# Altium schematic sheet root.SchDoc
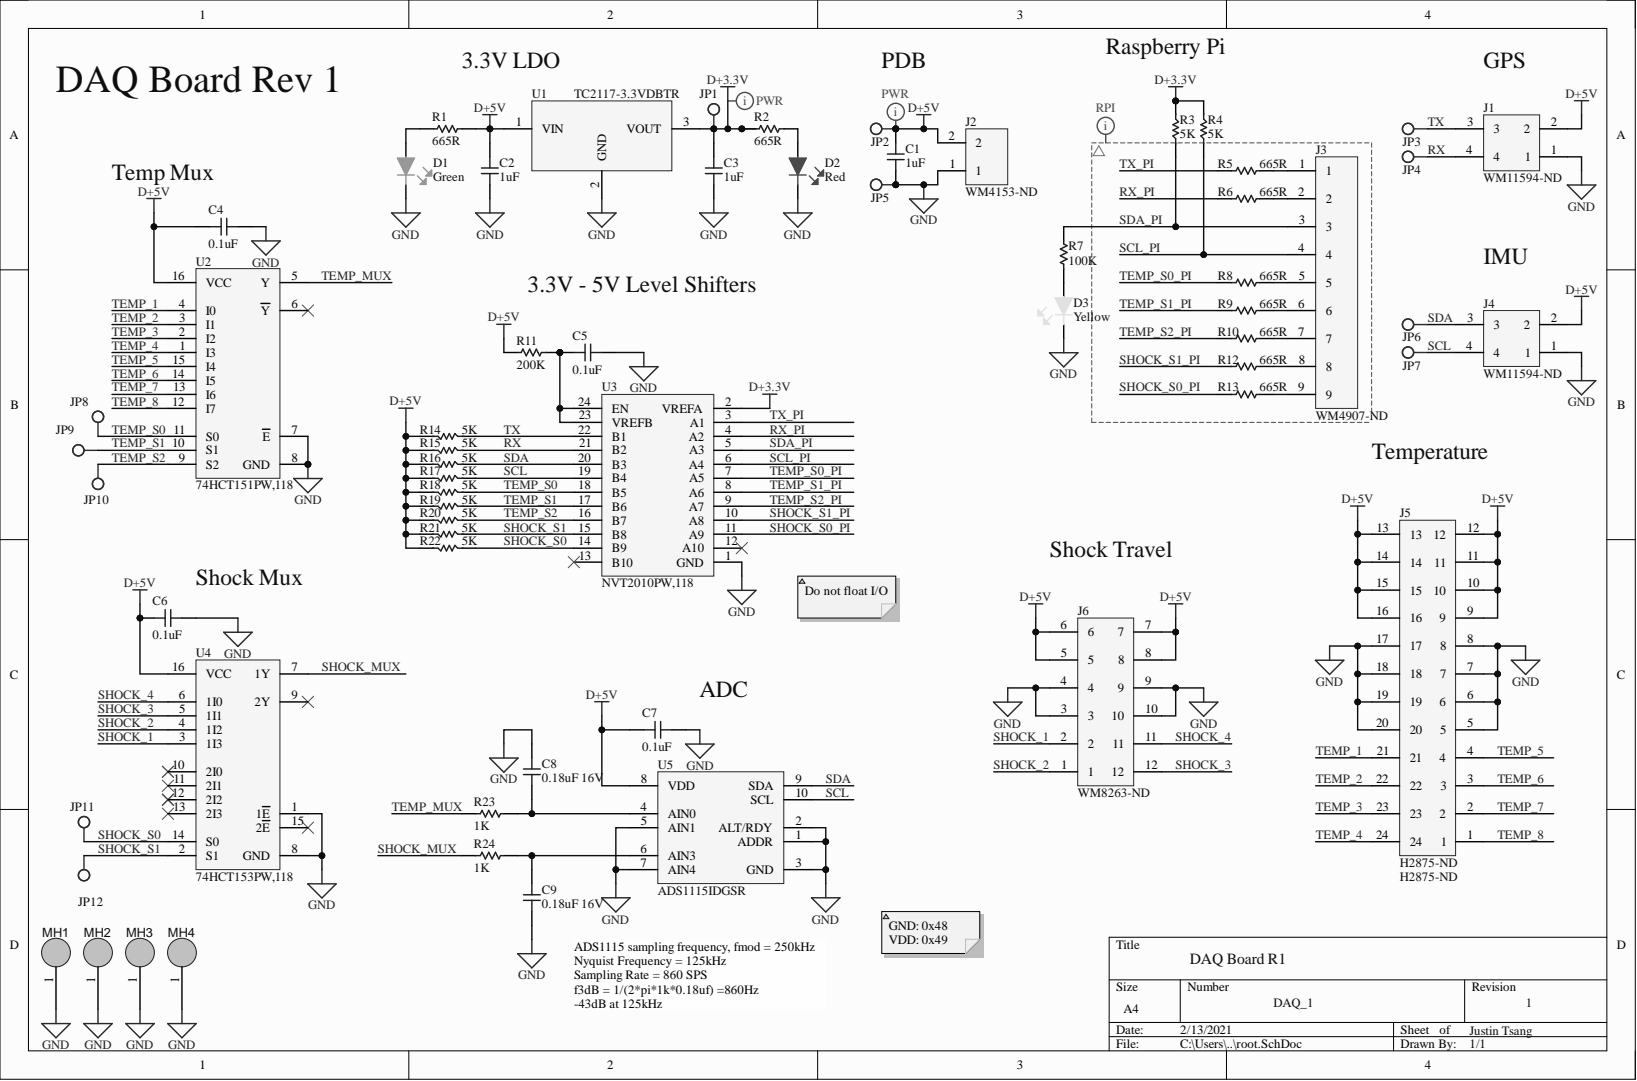
[diagram p0: root.SchDoc]

## Parts
C1  1uF  [CAP-0603]
C2  1uF  [CAP-0603]
C3  1uF  [CAP-0603]
C4  0.1uF  [CAP-0603]
C5  0.1uF  [CAP-0603]
C6  0.1uF  [CAP-0603]
C7  0.1uF  [CAP-0603]
C8  0.18uF 16V  [CAP-0603]
C9  0.18uF 16V  [CAP-0603]
D1  Green  [LED-0603]
D2  Red  [LED-0603]
D3  Yellow  [LED-0603]
J1  WM11594-ND  [2X2PIN]
J2  WM4153-ND  [2PIN]
J3  WM4907-ND  [9PIN]
J4  WM11594-ND  [2X2PIN]
J5  H2875-ND  [2X12PIN]
J6  WM8263-ND  [2X6PIN]
JP1  Testpoint2  [TP2]  (value not drawn)
JP2  Testpoint2  [TP2]  (value not drawn)
JP3  Testpoint2  [TP2]  (value not drawn)
JP4  Testpoint2  [TP2]  (value not drawn)
JP5  Testpoint2  [TP2]  (value not drawn)
JP6  Testpoint2  [TP2]  (value not drawn)
JP7  Testpoint2  [TP2]  (value not drawn)
JP8  Testpoint2  [TP2]  (value not drawn)
JP9  Testpoint2  [TP2]  (value not drawn)
JP10  Testpoint2  [TP2]  (value not drawn)
JP11  Testpoint2  [TP2]  (value not drawn)
JP12  Testpoint2  [TP2]  (value not drawn)
MH1  MountingHole  [MH4.2MM]  (value not drawn)
MH2  MountingHole  [MH4.2MM]  (value not drawn)
MH3  MountingHole  [MH4.2MM]  (value not drawn)
MH4  MountingHole  [MH4.2MM]  (value not drawn)
R1  665R  [RES-0603]
R2  665R  [RES-0603]
R3  5K  [RES-0603]
R4  5K  [RES-0603]
R5  665R  [RES-0603]
R6  665R  [RES-0603]
R7  100K  [RES-0603]
R8  665R  [RES-0603]
R9  665R  [RES-0603]
R10  665R  [RES-0603]
R11  200K  [RES-0603]
R12  665R  [RES-0603]
R13  665R  [RES-0603]
R14  5K  [RES-0603]
R15  5K  [RES-0603]
R16  5K  [RES-0603]
R17  5K  [RES-0603]
R18  5K  [RES-0603]
R19  5K  [RES-0603]
R20  5K  [RES-0603]
R21  5K  [RES-0603]
R22  5K  [RES-0603]
R23  1K  [RES-0603]
R24  1K  [RES-0603]
U1  TC2117-3.3VDBTR  [SOT223]
U2  74HCT151PW,118  [TSSOP65P660X110-16]
U3  NVT2010PW,118  [TSSOP65P660X110-24]
U4  74HCT153PW,118  [TSSOP65P660X110-16]
U5  ADS1115IDGSR  [VSSOP50P310X310-10P]

## Nets
D+3.3V: C3.2 JP1.1 R2.2 R3.2 R4.2 U1.3 U3.2(VREFA)
D+5V: C1.2 C2.2 C4.2 C6.2 C7.2 J1.2 J2.2 J4.2 J5.9 J5.10 J5.11 J5.12 J5.13 J5.14 J5.15 J5.16 J6.5 J6.6 J6.7 J6.8 JP2.1 R1.1 R11.2 R14.2 R15.1 R16.1 R17.1 R18.1 R19.1 R20.1 R21.1 R22.1 U1.1 U2.16(VCC) U4.16(VCC) U5.8(VDD)
GND: C1.1 C2.1 C3.1 C4.1 C5.1 C6.1 C7.1 C8.1 C9.1 D1.2 D2.2 D3.2 J1.1 J2.1 J4.1 J5.5 J5.6 J5.7 J5.8 J5.17 J5.18 J5.19 J5.20 J6.3 J6.4 J6.9 J6.10 JP5.1 MH1.1 MH2.1 MH3.1 MH4.1 U1.2 U2.7(E) U2.8(GND) U3.1(GND) U4.1(1E) U4.8(GND) U5.1(ADDR) U5.2(ALT/RDY) U5.3(GND) U5.5(AIN1) U5.7(AIN4)
RX: J1.4 JP4.1 R15.2 U3.21(B2)
RX_PI: R6.1 U3.4(A2)
SCL: J4.4 JP7.1 R17.2 U3.19(B4) U5.10(SCL)
SCL_PI: J3.4 R4.1 U3.6(A4)
SDA: J4.3 JP6.1 R16.2 U3.20(B3) U5.9(SDA)
SDA_PI: J3.3 R3.1 R7.2 U3.5(A3)
SHOCK_1: J6.2 U4.3(1I3)
SHOCK_2: J6.1 U4.4(1I2)
SHOCK_3: J6.12 U4.5(1I1)
SHOCK_4: J6.11 U4.6(1I0)
SHOCK_MUX: R24.1 U4.7(1Y)
SHOCK_S0: JP11.1 R22.2 U3.14(B9) U4.14(S0)
SHOCK_S0_PI: R13.1 U3.11(A9)
SHOCK_S1: JP12.1 R21.2 U3.15(B8) U4.2(S1)
SHOCK_S1_PI: R12.1 U3.10(A8)
TEMP_1: J5.21 U2.4(I0)
TEMP_2: J5.22 U2.3(I1)
TEMP_3: J5.23 U2.2(I2)
TEMP_4: J5.24 U2.1(I3)
TEMP_5: J5.4 U2.15(I4)
TEMP_6: J5.3 U2.14(I5)
TEMP_7: J5.2 U2.13(I6)
TEMP_8: J5.1 U2.12(I7)
TEMP_MUX: R23.1 U2.5(Y)
TEMP_S0: JP8.1 R18.2 U2.11(S0) U3.18(B5)
TEMP_S0_PI: R8.1 U3.7(A5)
TEMP_S1: JP9.1 R19.2 U2.10(S1) U3.17(B6)
TEMP_S1_PI: R9.1 U3.8(A6)
TEMP_S2: JP10.1 R20.2 U2.9(S2) U3.16(B7)
TEMP_S2_PI: R10.1 U3.9(A7)
TX: J1.3 JP3.1 R14.1 U3.22(B1)
TX_PI: R5.1 U3.3(A1)
NetC5_2: C5.2 R11.1 U3.23(VREFB) U3.24(EN)
NetC8_2: C8.2 R23.2 U5.4(AIN0)
NetC9_2: C9.2 R24.2 U5.6(AIN3)
NetD1_1: D1.1 R1.2
NetD2_1: D2.1 R2.1
NetD3_1: D3.1 R7.1
NetJ3_1: J3.1 R5.2
NetJ3_2: J3.2 R6.2
NetJ3_5: J3.5 R8.2
NetJ3_6: J3.6 R9.2
NetJ3_7: J3.7 R10.2
NetJ3_8: J3.8 R12.2
NetJ3_9: J3.9 R13.2

Not connected: U2 6(Y); U3 12(A10) 13(B10); U4 9(2Y) 10(2I0) 11(2I1) 12(2I2) 13(2I3) 15(2E)

## Library data of the parts
C1-C3 = 0603-1uF-16V: CAP CER 1UF 16V X7R 0603; Manufacturer: KEMET; Manufacturer Part Number: C0603C105K4RACTU; Dielectric Material: Ceramic; Dissipation Factor: 3.5%; Tolerance: 10%; Capacitance: 1uF; Case Code (Imperial): 0603; Case Code (Metric): 1608; Case/Package: 0603; Component Kind: Standard; ComponentLink1Description: http://www.mouser.com/ds/2/212/KEM_C1002_X7R_SMD-1102033.pdf?src-supplier=Mouser; ComponentLink1URL: http://www.mouser.com/ds/2/212/KEM_C1002_X7R_SMD-1102033.pdf?src-supplier=Mouser; ComponentLink2Description: http://www.kemet.com/kemet/web/homepage/kechome.nsf/file/Commercial%20Ceramic%20Chips%20X7R%20Dielectric/$file/KEM_C1002_X7R_SMD.pdf?src-supplier=Element14; ComponentLink2URL: http://www.kemet.com/kemet/web/homepage/kechome.nsf/file/Commercial%20Ceramic%20Chips%20X7R%20Dielectric/$file/KEM_C1002_X7R_SMD.pdf?src-supplier=Element14; ComponentLink3Description: https://www.verical.com/server-webapp/html/getDatasheet?partID=385479&src-supplier=Verical; ComponentLink3URL: https://www.verical.com/server-webapp/html/getDatasheet?partID=385479&src-supplier=Verical; ComponentLink4Description: http://www.kemet.com/kemet/web/homepage/kechome.nsf/vapubfiles/KEM_C1002_X7R_SMD.pdf/$file/KEM_C1002_X7R_SMD.pdf?src-supplier=Element14; ComponentLink4URL: http://www.kemet.com/kemet/web/homepage/kechome.nsf/vapubfiles/KEM_C1002_X7R_SMD.pdf/$file/KEM_C1002_X7R_SMD.pdf?src-supplier=Element14; Contact Plating: Tin,Matte; Depth: 0.8mm; Dielectric: X7R; Height: 0.8mm; Length: 1.6mm; Library Name: Capacitors.SchLib; Max Operating Temperature: 125°C; Min Operating Temperature: -55°C; Mount: Surface Mount,PCB; Number of Pins: 2; Package Quantity: 4000; Packaging: Tape and Reel; Pricing 1: 1=0.12, 10=0.088, 100=0.0391, 500=0.02792, 1000=0.02193 (USD); Radiation Hardening: No; REACH SVHC: No SVHC; Stock 1: 600463; Supplier 1: Digi-Key; Supplier Part Number 1: 399-7847-6-ND; Termination: SMD/SMT; Thickness: 0.034inch; Voltage: 16V; Voltage Rating: 16V; Voltage Rating (DC): 16V; Width: 0.031inch
C4-C7 = 0603-0.1uF-16V: CAP CER 0.1UF 16V X7R 0603; Manufacturer: KEMET; Manufacturer Part Number: C0603C104M4RACTU; Capacitance: 100nF; Dielectric Material: Ceramic; Tolerance: 20%; Case Code (Imperial): 0603; Case Code (Metric): 1608; Case/Package: 0603; Component Kind: Standard; ComponentLink1Description: https://www.verical.com/server-webapp/html/getDatasheet?partID=66190&src-supplier=Verical; ComponentLink1URL: https://www.verical.com/server-webapp/html/getDatasheet?partID=66190&src-supplier=Verical; ComponentLink2Description: http://www.farnell.com/datasheets/2746055.pdf?src-supplier=Element14; ComponentLink2URL: http://www.farnell.com/datasheets/2746055.pdf?src-supplier=Element14; ComponentLink3Description: http://www.farnell.com/datasheets/2123765.pdf?src-supplier=Element14; ComponentLink3URL: http://www.farnell.com/datasheets/2123765.pdf?src-supplier=Element14; ComponentLink4Description: http://www.alliedelec.com/m/d/9bfe7773041ffe9d406e68bc7b377fdd.pdf?src-supplier=Allied+Electronics; ComponentLink4URL: http://www.alliedelec.com/m/d/9bfe7773041ffe9d406e68bc7b377fdd.pdf?src-supplier=Allied+Electronics; ComponentLink5Description: https://www.verical.com/server-webapp/html/getDatasheet?partID=302288&src-supplier=Verical; ComponentLink5URL: https://www.verical.com/server-webapp/html/getDatasheet?partID=302288&src-supplier=Verical; Depth: 0.8mm; Dielectric: X7R; Height: 0.8mm; Lead Pitch: 0.7mm; Length: 1.6mm; Library Name: Capacitors.SchLib; Max Operating Temperature: 125°C; Min Operating Temperature: -55°C; Mount: Surface Mount,PCB; Number of Pins: 2; Package Quantity: 1; Packaging: Tape and Reel; Pricing 1: 1=0.1, 10=0.036, 100=0.0161, 500=0.01152, 1000=0.00904 (USD); Radiation Hardening: No; REACH SVHC: No SVHC; Stock 1: 254524; Supplier 1: Digi-Key; Supplier Part Number 1: 399-1099-6-ND; Termination: SMD/SMT; Thickness: 0.034inch; Voltage Rating: 16V; Voltage Rating (DC): 16V; Width: 0.031inch
C8 C9 = 0603-0.18uF-16V: Cap Ceramic 0.18uF 16V X7R 10% SMD 0603 125C Paper T/R; Manufacturer: Yageo; Manufacturer Part Number: CC0603KRX7R7BB184; Capacitance: 180nF; Case Code (Imperial): 0603; Case Code (Metric): 1608; Case/Package: 0603; Category: Ceramic Capacitors; Component Kind: Standard; ComponentLink1Description: https://datasheet.ciiva.com/11465/upy-gphc-x7r-6-3v-to-50v-8-11465175.pdf?src-supplier=Avnet; ComponentLink1URL: https://datasheet.ciiva.com/11465/upy-gphc-x7r-6-3v-to-50v-8-11465175.pdf?src-supplier=Avnet; ComponentLink2Description: https://datasheet.ciiva.com/17434/upy-gphc-x7r-6-3v-to-50v-8-17434591.pdf?src-supplier=Avnet+Europe; ComponentLink2URL: https://datasheet.ciiva.com/17434/upy-gphc-x7r-6-3v-to-50v-8-17434591.pdf?src-supplier=Avnet+Europe; Construction: Flat; Depth: 0.8mm; Dielectric: X7R; Halogen Free: Halogen Free; Lead Free: Lead Free; Length: 1.6mm; Library Name: New_Parts.SchLib; Max Operating Temperature: 125°C; Min Operating Temperature: -55°C; Mount: Surface Mount; Packaging: Tape and Reel; Pricing 1: 1=0.20000, 10=0.14500, 50=0.08220, 100=0.06820, 500=0.04780, 1000=0.03958 (USD); Radiation Hardening: No; Resistor Type: Ceramic; RoHS: Yes; RoHS Compliant: Yes; Series: CC; Stock 1: 8000; Supplier 1: Digi-Key; Supplier Part Number 1: 311-4066-1-ND; Termination: SMD/SMT; Thickness: 0.035inch; Tolerance: 10%; Voltage: 16V; Voltage Rating: 16V; Voltage Rating (DC): 16V; Weight: 7.1E-05oz; Width: 0.031inch
D1 = LED-Green: LED GREEN CLEAR 0603 SMD; Manufacturer: Wurth Electronics; Manufacturer Part Number: 150060VS75000; Dominant Wavelength: 570nm; Forward Current: 30mA; Forward Voltage: 2V; Lens Style: Clear,Rectangular; Luminous Intensity: 40mcd; Test Current: 20mA; Viewing Angle: 140°; Case Code (Imperial): 0603; Case Code (Metric): 1608; Case/Package: 0603; Color: Green; Component Kind: Standard; ComponentLink1Description: https://katalog.we-online.de/led/datasheet/150060VS75000.pdf?src-supplier=Digi-Key; ComponentLink1URL: https://katalog.we-online.de/led/datasheet/150060VS75000.pdf?src-supplier=Digi-Key; Height: 0.7mm; Length: 1.6mm; Library Name: LEDs.SchLib; Max Operating Temperature: 85°C; Min Operating Temperature: -40°C; Mount: Surface Mount; Number of Pins: 2; Package Quantity: 1; Packaging: Tape and Reel; Pricing 1: 1=0.14, 50=0.133, 100=0.114, 500=0.099 (USD); REACH SVHC: Unknown; Stock 1: 118118; Supplier 1: Digi-Key; Supplier Part Number 1: 732-4980-1-ND; Wavelength: 570nm; Width: 0.8mm
D2 = LED-Red: LED RED CLEAR 0603 SMD; Manufacturer: Wurth Electronics; Manufacturer Part Number: 150060RS75000; Dominant Wavelength: 625nm; Forward Current: 30mA; Forward Voltage: 2V; Lens Style: Clear,Rectangular; Luminous Intensity: 250mcd; Test Current: 20mA; Viewing Angle: 140°; Case Code (Imperial): 0603; Case Code (Metric): 1608; Case/Package: 0603; Color: Red; Component Kind: Standard; ComponentLink1Description: https://katalog.we-online.de/led/datasheet/150060RS75000.pdf?src-supplier=Digi-Key; ComponentLink1URL: https://katalog.we-online.de/led/datasheet/150060RS75000.pdf?src-supplier=Digi-Key; ComponentLink2Description: http://www.produktinfo.conrad.com/datenblaetter/750000-774999/759428-da-01-en-CHIPLED_0603_W_KL_ROT_150060RS75000.pdf?src-supplier=Conrad; ComponentLink2URL: http://www.produktinfo.conrad.com/datenblaetter/750000-774999/759428-da-01-en-CHIPLED_0603_W_KL_ROT_150060RS75000.pdf?src-supplier=Conrad; Height: 0.7mm; Length: 1.6mm; Library Name: LEDs.SchLib; Max Operating Temperature: 85°C; Min Operating Temperature: -40°C; Mount: Surface Mount; Package Quantity: 1; Packaging: Cut Tape; Pricing 1: 1=0.14, 50=0.133, 100=0.114, 500=0.099 (USD); REACH SVHC: Unknown; Stock 1: 18518; Supplier 1: Digi-Key; Supplier Part Number 1: 732-4978-6-ND; Wavelength: 625nm; Width: 0.8mm
D3 = LED-Yellow: LED YELLOW CLEAR 0603 SMD; Manufacturer: Wurth Electronics; Manufacturer Part Number: 150060YS75000; Dominant Wavelength: 590nm; Forward Current: 30mA; Forward Voltage: 2V; Lens Style: Clear,Rectangular; Luminous Intensity: 120mcd; Test Current: 20mA; Viewing Angle: 140°; Case Code (Imperial): 0603; Case Code (Metric): 1608; Case/Package: 0603; Color: Yellow; Component Kind: Standard; ComponentLink1Description: http://katalog.we-online.de/led/datasheet/150060YS75000.pdf?src-supplier=Newark; ComponentLink1URL: http://katalog.we-online.de/led/datasheet/150060YS75000.pdf?src-supplier=Newark; ComponentLink2Description: https://katalog.we-online.de/led/datasheet/150060YS75000.pdf?src-supplier=Digi-Key; ComponentLink2URL: https://katalog.we-online.de/led/datasheet/150060YS75000.pdf?src-supplier=Digi-Key; ComponentLink3Description: http://www.farnell.com/datasheets/1911348.pdf?src-supplier=Element14; ComponentLink3URL: http://www.farnell.com/datasheets/1911348.pdf?src-supplier=Element14; ComponentLink4Description: https://www.distrelec.biz/Web/Downloads/_t/ds/150060YS75000_eng_tds.pdf?mime=application%2Fpdf&src-supplier=Distrelec; ComponentLink4URL: https://www.distrelec.biz/Web/Downloads/_t/ds/150060YS75000_eng_tds.pdf?mime=application%2Fpdf&src-supplier=Distrelec; ComponentLink5Description: http://www.produktinfo.conrad.com/datenblaetter/750000-774999/759432-da-01-en-CHIPLED_0603_W_KL_GELB_150060YS75000.pdf?src-supplier=Conrad; ComponentLink5URL: http://www.produktinfo.conrad.com/datenblaetter/750000-774999/759432-da-01-en-CHIPLED_0603_W_KL_GELB_150060YS75000.pdf?src-supplier=Conrad; Height: 0.7mm; Length: 1.6mm; Library Name: LEDs.SchLib; Max Operating Temperature: 85°C; Min Operating Temperature: -40°C; Mount: Surface Mount; Package Quantity: 4000; Packaging: Tape and Reel; Pricing 1: 1=0.14, 50=0.133, 100=0.114, 500=0.099 (USD); REACH SVHC: Unknown; Stock 1: 78; Supplier 1: Digi-Key; Supplier Part Number 1: 732-4981-6-ND; Wavelength: 590nm; Width: 0.8mm
J1 J4 = 2X2PIN: CONN HEADER R/A 4POS 3.5MM; Manufacturer: Molex; Manufacturer Part Number: 172316-1104; Connector Type: Male Pin; Gender: Male; Number of Circuits: 4; Pitch: 0.138inch; Row Spacing: 0.181inch; Color: Black; Component Kind: Standard; ComponentLink1Description: http://www.alliedelec.com/images/products/datasheets/bm/MOLEX/70617684.pdf?src-supplier=Allied+Electronics; ComponentLink1URL: http://www.alliedelec.com/images/products/datasheets/bm/MOLEX/70617684.pdf?src-supplier=Allied+Electronics; ComponentLink2Description: http://www.farnell.com/datasheets/1944206.pdf?src-supplier=Element14; ComponentLink2URL: http://www.farnell.com/datasheets/1944206.pdf?src-supplier=Element14; ComponentLink3Description: http://www.farnell.com/datasheets/2214524.pdf?src-supplier=Farnell; ComponentLink3URL: http://www.farnell.com/datasheets/2214524.pdf?src-supplier=Farnell; ComponentLink4Description: https://www.molex.com/pdm_docs/sd/1723161104_sd.pdf?src-supplier=Digi-Key; ComponentLink4URL: https://www.molex.com/pdm_docs/sd/1723161104_sd.pdf?src-supplier=Digi-Key; ComponentLink5Description: http://www.farnell.com/datasheets/1955765.pdf?src-supplier=Element14; ComponentLink5URL: http://www.farnell.com/datasheets/1955765.pdf?src-supplier=Element14; Contact Material: Copper Alloy; Contact Plating: Tin; Fastening Type: Latch; Library Name: Connectors.SchLib; Mount: Through Hole; Number of Contacts: 4; Number of Pins: 4; Number of Positions: 4; Number of Rows: 2; Packaging: Tray; Pricing 1: 1=0.93, 10=0.814, 100=0.7027, 500=0.57492, 1000=0.51104, 5000=0.44716, 10000=0.4248 (USD); REACH SVHC: Unknown; Stock 1: 4392; Supplier 1: Digi-Key; Supplier Part Number 1: WM11594-ND; Termination: Solder
J2 = 2PIN: CONN HEADER R/A 2POS 2.54MM; Manufacturer: Molex; Manufacturer Part Number: 0705530106; Connector Type: Male Pin; Contact Resistance: 30mR; Number of Contacts: 2; Color: Black; Component Kind: Standard; ComponentLink1Description: http://www.molex.com/webdocs/datasheets/pdf/en-us/0705530106_PCB_HEADERS.pdf?src-supplier=Avnet+Asia; ComponentLink1URL: http://www.molex.com/webdocs/datasheets/pdf/en-us/0705530106_PCB_HEADERS.pdf?src-supplier=Avnet+Asia; ComponentLink2Description: https://www.molex.com/pdm_docs/ps/PS-70400.pdf?src-supplier=Digi-Key; ComponentLink2URL: https://www.molex.com/pdm_docs/ps/PS-70400.pdf?src-supplier=Digi-Key; Contact Material: Bronze; Contact Mating Length: 0.24inch; Contact Plating: Gold; Depth: 13.59mm; Housing Color: Black; Housing Material: Polyester; Length: 7.37mm; Library Name: Connectors.SchLib; Max Operating Temperature: 105°C; Min Operating Temperature: -40°C; Mount: Through Hole; Number of Positions: 2; Number of Rows: 1; Orientation: Right Angle; Packaging: Rail/Tube; Pitch: 2.54mm; Pricing 1: 1=1.38, 10=1.25, 100=1.071, 500=0.8925, 1000=0.765, 5000=0.6783, 10000=0.663 (USD); Stock 1: 2224; Supplier 1: Digi-Key; Supplier Part Number 1: WM4153-ND; Termination: Solder
J3 = 9PIN: CONN HEADER R/A 9POS 2.54MM; Manufacturer: Molex; Manufacturer Part Number: 70553-0112; Connector Type: Male Pin; Contact Resistance: 30mR; Current Rating: 3A; Glow Wire Compliant: Non-compliant; Insulation Resistance: 1GR; Number of Circuits: 8; Voltage Rating: 250V; Color: Black; Component Kind: Standard; ComponentLink1Description: https://www.molex.com/pdm_docs/ps/PS-70400.pdf?src-supplier=Digi-Key; ComponentLink1URL: https://www.molex.com/pdm_docs/ps/PS-70400.pdf?src-supplier=Digi-Key; ComponentLink2Description: http://www.mouser.com/ds/2/276/0705530112_PCB_HEADERS-172840.pdf?src-supplier=Mouser; ComponentLink2URL: http://www.mouser.com/ds/2/276/0705530112_PCB_HEADERS-172840.pdf?src-supplier=Mouser; Contact Material: Bronze; Contact Mating Length: 0.24inch; Contact Plating: Gold; ELV: Compliant; Flammability Rating: UL94 V-0; Housing Material: Thermoplastic; Library Name: New_Parts.SchLib; Material: Brass; Max Operating Temperature: 105°C; Min Operating Temperature: -40°C; Mount: Through Hole; Number of Contacts: 8; Number of Positions: 8; Number of Rows: 1; Orientation: Right Angle; Package Quantity: 1; Packaging: Rail/Tube; Pitch: 2.54mm; Pricing 1: 1=2.43, 10=2.205, 100=1.89, 500=1.575, 1000=1.35, 5000=1.197, 10000=1.17 (USD); Stock 1: 1363; Supplier 1: Digi-Key; Supplier Part Number 1: 23-0705530112-ND; Termination: Solder; Voltage Rating (DC): 250V
J5 = 2X12PIN: CONN HEADER R/A 24POS 2MM; Component Kind: Standard; ComponentLink1Description: http://docs-usa.electrocomponents.com/techlib:/itc_doclist_US.htm?stockno=6888963&src-supplier=Allied+Electronics; ComponentLink1URL: http://docs-usa.electrocomponents.com/techlib:/itc_doclist_US.htm?stockno=6888963&src-supplier=Allied+Electronics; ComponentLink2Description: https://www.verical.com/server-webapp/html/getDatasheet?partID=456315&src-supplier=Verical; ComponentLink2URL: https://www.verical.com/server-webapp/html/getDatasheet?partID=456315&src-supplier=Verical; ComponentLink3Description: http://www.hirose.co.jp/cataloge_hp/en_DF11_20130411.pdf?src-supplier=Future+Electronics; ComponentLink3URL: http://www.hirose.co.jp/cataloge_hp/en_DF11_20130411.pdf?src-supplier=Future+Electronics; ComponentLink4Description: http://www.farnell.com/datasheets/91550.pdf?src-supplier=Element14; ComponentLink4URL: http://www.farnell.com/datasheets/91550.pdf?src-supplier=Element14; ComponentLink5Description: http://www.mouser.com/ds/2/185/DF11_catalog-1064296.pdf?src-supplier=Mouser; ComponentLink5URL: http://www.mouser.com/ds/2/185/DF11_catalog-1064296.pdf?src-supplier=Mouser; ComponentLink6Description: http://documents.rs-components.com/techlib://itc_doclist_GB.htm?stockno=6056598&src-supplier=RSComponents; ComponentLink6URL: http://documents.rs-components.com/techlib://itc_doclist_GB.htm?stockno=6056598&src-supplier=RSComponents; Library Name: New_Parts.SchLib; Supplier 1: Digi-Key; Supplier Part Number 1: H2875-ND
J6 = 2X6PIN: CONN HEADER R/A 12POS 2.54MM; Manufacturer: Molex; Manufacturer Part Number: 90130-3110; Connector Type: Male Pin; Current Rating: 3A; Gender: Male; Glow Wire Compliant: Non-compliant; Max Voltage Rating (AC): 350V; Max Voltage Rating (DC): 350.35kV; Number of Circuits: 10; Row Spacing: 0.1inch; Color: Black; Component Kind: Standard; ComponentLink1Description: http://www.mouser.com/ds/2/276/0901303110_PCB_HEADERS-151522.pdf?src-supplier=Mouser; ComponentLink1URL: http://www.mouser.com/ds/2/276/0901303110_PCB_HEADERS-151522.pdf?src-supplier=Mouser; ComponentLink2Description: http://uk.rs-online.com/webdocs/13c4/0900766b813c4c8e.pdf?src-supplier=RSComponents; ComponentLink2URL: http://uk.rs-online.com/webdocs/13c4/0900766b813c4c8e.pdf?src-supplier=RSComponents; ComponentLink3Description: http://www.molex.com/webdocs/datasheets/pdf/en-us/0901303110_PCB_HEADERS.pdf?src-supplier=Avnet; ComponentLink3URL: http://www.molex.com/webdocs/datasheets/pdf/en-us/0901303110_PCB_HEADERS.pdf?src-supplier=Avnet; ComponentLink4Description: http://www.molex.com/pdm_docs/sd/901303110_sd.pdf?src-supplier=Digi-Key; ComponentLink4URL: http://www.molex.com/pdm_docs/sd/901303110_sd.pdf?src-supplier=Digi-Key; ComponentLink5Description: http://www.molex.com/webdocs/datasheets/pdf/en-us/0901303110_PCB_HEADERS.pdf?src-supplier=Avnet+Europe; ComponentLink5URL: http://www.molex.com/webdocs/datasheets/pdf/en-us/0901303110_PCB_HEADERS.pdf?src-supplier=Avnet+Europe; Contact Material: Brass; Contact Mating Length: 0.266inch; Contact Plating: Tin,Lead; Depth: 9.75mm; Flammability Rating: UL94 V-0; Housing Color: Black; Housing Material: Polyester; Lead Free: Lead Free; Length: 15.42mm; Library Name: New_Parts.SchLib; Max Operating Temperature: 125°C; Min Operating Temperature: -55°C; Mount: Through Hole; Number of Contacts: 10; Number of Positions: 10; Number of Rows: 2; Orientation: Right Angle; Package Quantity: 1; Packaging: Tray; Pitch: 2.54mm; Pricing 1: 1=1.47, 10=1.329, 100=1.1395, 500=0.94956, 1000=0.8139, 5000=0.72166, 10000=0.70538 (USD); Radiation Hardening: No; REACH SVHC: No SVHC; Stock 1: 3596; Supplier 1: Digi-Key; Supplier Part Number 1: WM8263-ND; Termination: Solder; Voltage Rating: 350V; Voltage Rating (DC): 350V
JP1-JP12 = Testpoint2: Single layer pad TP; Component Kind: Standard; Library Name: Misc.SchLib
MH1-MH4 = MH4.2mm: Mounting hole, 4.2mm; Case: TH; Component Kind: Standard; Library Name: New_Parts.SchLib
R1 R2 R5 R6 R8-R10 R12 R13 = 0603-665R: RES SMD 665 OHM 1% 1/10W 0603; Manufacturer: Yageo; Manufacturer Part Number: RC0603FR-07665RL; Max Power Dissipation: 100mW; Temperature Coefficient: 100ppm/°C; Voltage Rating: 75V; Voltage Rating (DC): 50V; Case Code (Imperial): 0603; Case Code (Metric): 1608; Case/Package: 0603; Component Kind: Standard; ComponentLink1Description: http://www.yageo.com/documents/recent/PYu-RC_Group_51_RoHS_L_9.pdf?src-supplier=Digi-Key; ComponentLink1URL: http://www.yageo.com/documents/recent/PYu-RC_Group_51_RoHS_L_9.pdf?src-supplier=Digi-Key; ComponentLink2Description: http://www.yageo.com/documents/recent/PYu-RC0603_51_RoHS_L_4.pdf?src-supplier=Avnet; ComponentLink2URL: http://www.yageo.com/documents/recent/PYu-RC0603_51_RoHS_L_4.pdf?src-supplier=Avnet; ComponentLink3Description: http://www.yageo.com/documents/recent/PYu-RC_Group_51_RoHS_L_10.pdf?src-supplier=Digi-Key; ComponentLink3URL: http://www.yageo.com/documents/recent/PYu-RC_Group_51_RoHS_L_10.pdf?src-supplier=Digi-Key; ComponentLink4Description: http://www.yageo.com/documents/recent/PYu-RC0603_51_RoHS_L_4.pdf?src-supplier=Avnet+Europe; ComponentLink4URL: http://www.yageo.com/documents/recent/PYu-RC0603_51_RoHS_L_4.pdf?src-supplier=Avnet+Europe; Composition: Thick Film; Contact Plating: Tin,Matte; Depth: 0.8mm; Features: Moisture Resistant; Height: 0.022inch; Lead Free: Lead Free; Length: 1.6mm; Library Name: Resistors.SchLib; Max Operating Temperature: 155°C; Military Standard: Not; Min Operating Temperature: -55°C; Number of Terminations: 2; Package Quantity: 1; Packaging: Tape and Reel; Power Rating: 100mW; Pricing 1: 1=0.1, 10=0.023, 100=0.0094, 1000=0.00421, 2500=0.00366 (USD); Resistance: 665R; Stock 1: 143871; Supplier 1: Digi-Key; Supplier Part Number 1: 311-665HRDKR-ND; Termination: SMD/SMT; Tolerance: 1%; Weight: 7.1E-05oz; Width: 0.8mm
R3 R4 R14-R22 = 0603-5KR: RES SMD 4.99K OHM 1% 1/10W 0603; Manufacturer: Bourns; Manufacturer Part Number: CR0603-FX-4991ELF; Max Power Dissipation: 100mW; Resistance: 4.99kR; Temperature Coefficient: 100ppm/°C; Voltage Rating: 50V; Case Code (Imperial): 0603; Case Code (Metric): 1608; Case/Package: 0603; Component Kind: Standard; ComponentLink1Description: https://www.bourns.com/docs/Product-Datasheets/CHPREZTR.pdf?src-supplier=Digi-Key; ComponentLink1URL: https://www.bourns.com/docs/Product-Datasheets/CHPREZTR.pdf?src-supplier=Digi-Key; ComponentLink2Description: http://www.bourns.com/pdfs/chpreztr.pdf?src-supplier=Avnet; ComponentLink2URL: http://www.bourns.com/pdfs/chpreztr.pdf?src-supplier=Avnet; Composition: Thick Film; Contact Plating: Tin; Features: Moisture Resistant; Height: 0.022inch; Length: 0.063inch; Library Name: Resistors.SchLib; Max Operating Temperature: 155°C; Min Operating Temperature: -55°C; Mount: Surface Mount; Number of Terminations: 2; Package Quantity: 1; Packaging: Tape and Reel; Power Rating: 100mW; Pricing 1: 1=0.1, 10=0.02, 25=0.0144, 50=0.011, 100=0.0082, 250=0.00624, 500=0.00498, 1000=0.00367 (USD); Radiation Hardening: No; Stock 1: 70557; Supplier 1: Digi-Key; Supplier Part Number 1: CR0603-FX-4991ELFDKR-ND; Termination: SMD/SMT; Thickness: 457um; Tolerance: 1%; Width: 0.8mm
R7 = 0603-100KR: RES 100K OHM 1% 1/10W 0603; Manufacturer: Stackpole Electronics; Manufacturer Part Number: RMCF0603FT100K; Max Power Dissipation: 100mW; Resistance: 100kR; Temperature Coefficient: 100ppm/°C; Working Voltage: 75V; Case Code (Imperial): 0603; Case Code (Metric): 1608; Case/Package: 0603; Component Kind: Standard; ComponentLink1Description: https://www.verical.com/server-webapp/html/getDatasheet?partID=362597&src-supplier=Verical; ComponentLink1URL: https://www.verical.com/server-webapp/html/getDatasheet?partID=362597&src-supplier=Verical; Composition: Thick Film; Contact Plating: Tin,Matte; Depth: 0.8mm; Features: Automotive AEC-Q200; Height: 0.022inch; Lead Free: Lead Free; Length: 1.55mm; Library Name: Resistors.SchLib; Max Operating Temperature: 155°C; Military Standard: Not; Min Operating Temperature: -55°C; Mount: Surface Mount; Number of Terminations: 2; Packaging: Tape and Reel; Power Rating: 100mW; Pricing 1: 1=0.1, 10=0.016, 100=0.0065, 1000=0.00292, 2500=0.00253 (USD); Radiation Hardening: No; Stock 1: 1428218; Supplier 1: Digi-Key; Supplier Part Number 1: RMCF0603FT100KDKR-ND; Tolerance: 1%; Width: 0.031inch
R11 = 0603-200KR: RES SMD 200K OHM 1% 1/10W 0603; Manufacturer: Yageo Phycomp; Manufacturer Part Number: RC0603FR-072K2L; Power Rating: 100mW; Resistance: 2.2kR; Temperature Coefficient: 100ppm/°C; Voltage Rating (DC): 50V; Case/Package: 0603; Component Kind: Standard; Depth: 0.8mm; Height: 0.45mm; Lead Free: Lead Free; Length: 1.6mm; Library Name: New_Parts.SchLib; Max Operating Temperature: 155°C; Min Operating Temperature: -55°C; Mount: Surface Mount; Number of Pins: 2; Packaging: Cut Tape; Pricing 1: 1=0.1, 10=0.023, 100=0.0094, 1000=0.00421, 2500=0.00366 (USD); REACH SVHC: No SVHC; Stock 1: 8205077; Supplier 1: Digi-Key; Supplier Part Number 1: 311-2.20KHRDKR-ND; Tolerance: 1%; Width: 800um
R23 R24 = 0603-1KR: RES SMD 1K OHM 1% 1/10W 0603; Manufacturer: Vishay Dale; Manufacturer Part Number: CRCW06031K00FKEA; Max Power Dissipation: 100mW; Resistance: 1kR; Temperature Coefficient: 100ppm/°C; Voltage Rating: 75V; Case Code (Imperial): 0603; Case Code (Metric): 1608; Case/Package: 0603; Component Kind: Standard; ComponentLink1Description: http://www.vishay.com/docs/20035/dcrcwe3.pdf?src-supplier=Digi-Key; ComponentLink1URL: http://www.vishay.com/docs/20035/dcrcwe3.pdf?src-supplier=Digi-Key; ComponentLink2Description: http://uk.rs-online.com/webdocs/0d98/0900766b80d98afc.pdf?src-supplier=RSComponents; ComponentLink2URL: http://uk.rs-online.com/webdocs/0d98/0900766b80d98afc.pdf?src-supplier=RSComponents; ComponentLink3Description: http://www.vishay.com/docs/20035/dcrcwe3.pdf?src-supplier=Avnet; ComponentLink3URL: http://www.vishay.com/docs/20035/dcrcwe3.pdf?src-supplier=Avnet; ComponentLink4Description: http://www.vishay.com/docs/20035/dcrcwe3.pdf?src-supplier=Avnet+Asia; ComponentLink4URL: http://www.vishay.com/docs/20035/dcrcwe3.pdf?src-supplier=Avnet+Asia; ComponentLink5Description: http://www.farnell.com/datasheets/2310790.pdf?src-supplier=Element14; ComponentLink5URL: http://www.farnell.com/datasheets/2310790.pdf?src-supplier=Element14; ComponentLink6Description: https://www.distrelec.biz/Web/Downloads/a_/en/flD-CRCW-e3_data_en.pdf?mime=application%2Fpdf&src-supplier=Distrelec; ComponentLink6URL: https://www.distrelec.biz/Web/Downloads/a_/en/flD-CRCW-e3_data_en.pdf?mime=application%2Fpdf&src-supplier=Distrelec; ComponentLink7Description: http://www.vishay.com/docs/20035/dcrcwe3.pdf?src-supplier=Future+Electronics; ComponentLink7URL: http://www.vishay.com/docs/20035/dcrcwe3.pdf?src-supplier=Future+Electronics; ComponentLink8Description: http://www.vishay.com/docs/20035/dcrcwe3.pdf?src-supplier=Avnet+Europe; ComponentLink8URL: http://www.vishay.com/docs/20035/dcrcwe3.pdf?src-supplier=Avnet+Europe; Composition: Thick Film; Contact Plating: Tin; Depth: 0.85mm; Features: Automotive AEC-Q200; Height: 0.45mm; Lead Free: Lead Free; Length: 1.55mm; Library Name: Resistors.SchLib; Max Operating Temperature: 155°C; Military Standard: Not; Min Operating Temperature: -55°C; Mount: Surface Mount; Number of Pins: 2; Number of Terminations: 2; Package Quantity: 10; Packaging: Tape and Reel; Power Rating: 100mW; Pricing 1: 1=0.1, 10=0.034, 100=0.0139, 1000=0.00623, 2500=0.0054 (USD); Radiation Hardening: No; REACH SVHC: Unknown; Stock 1: 671453; Supplier 1: Digi-Key; Supplier Part Number 1: 541-1.00KHCT-ND; Termination: SMD/SMT; Tolerance: 1%; Voltage Rating (DC): 75V; Weight: 7.1E-05oz; Width: 0.033inch
U1 = LDO-3.3V-800mA: IC REG LIN 3.3V 800MA SOT223-3; Manufacturer: Microchip; Accuracy: 0.5%; Dropout Voltage: 1.2V; Max Input Voltage: 6V; Min Input Voltage: 2.7V; Number of Outputs: 1; Output Type: Fixed; Polarity: Positive; Quiescent Current: 80uA; Case/Package: SOT-223-3; Component Kind: Standard; ComponentLink1Description: http://www.farnell.com/datasheets/1669439.pdf?src-supplier=Element14; ComponentLink1URL: http://www.farnell.com/datasheets/1669439.pdf?src-supplier=Element14; ComponentLink2Description: http://ww1.microchip.com/downloads/en/DeviceDoc/21665D.pdf?src-supplier=Avnet+Europe; ComponentLink2URL: http://ww1.microchip.com/downloads/en/DeviceDoc/21665D.pdf?src-supplier=Avnet+Europe; ComponentLink3Description: http://www.microchip.com/mymicrochip/filehandler.aspx?ddocname=en023833&src-supplier=Digi-Key; ComponentLink3URL: http://www.microchip.com/mymicrochip/filehandler.aspx?ddocname=en023833&src-supplier=Digi-Key; ComponentLink4Description: http://ww1.microchip.com/downloads/en/DeviceDoc/21665D.pdf?src-supplier=Future+Electronics; ComponentLink4URL: http://ww1.microchip.com/downloads/en/DeviceDoc/21665D.pdf?src-supplier=Future+Electronics; ComponentLink5Description: http://ww1.microchip.com/downloads/en/DeviceDoc/21665D.pdf?src-supplier=Avnet; ComponentLink5URL: http://ww1.microchip.com/downloads/en/DeviceDoc/21665D.pdf?src-supplier=Avnet; ComponentLink6Description: http://www.farnell.com/datasheets/1669439.pdf?src-supplier=Newark; ComponentLink6URL: http://www.farnell.com/datasheets/1669439.pdf?src-supplier=Newark; Height: 1.7mm; Lead Free: Lead Free; Length: 6.7mm; Library Name: Power.SchLib; Manufacturer Part Number: TC2117-3.3VDBTR; Max Operating Temperature: 125°C; Max Output Current: 800mA; Max Output Voltage: 3.3V; Max Supply Voltage: 6V; Min Operating Temperature: -40°C; Min Output Voltage: 3.3V; Min Supply Voltage: 2.7V; Mount: Surface Mount; Nominal Output Voltage: 3.3V; Number of Pins: 3; Number of Regulators: 1; Output Current: 800mA; Output Voltage: 3.3V; Package Quantity: 4000; Packaging: Tape and Reel; Pricing 1: 1=0.73, 25=0.61, 100=0.55 (USD); Radiation Hardening: No; REACH SVHC: No SVHC; Stock 1: 3126; Supplier 1: Digi-Key; Supplier Part Number 1: TC2117-3.3VDBDKR-ND; Termination: SMD/SMT; Width: 3.7mm
U2 = IC_74HCT151: Multiplexer 1-Element CMOS 8-IN 16-Pin TSSOP T/R; Manufacturer: NXP Semiconductors; High Level Output Current: -4mA; Independent Circuits: 1; Logic Function: Multiplexer,Mux; Low Level Output Current: 4mA; Max Supply Voltage: 5.5V; Min Supply Voltage: 4.5V; Number of Input Lines: 8; Operating Supply Voltage: 5V; Power Dissipation: 500mW; Propagation Delay: 38ns; Supply Type: Single; Case/Package: TSSOP; Category: Decoders and Multiplexers; Component Kind: Standard; ComponentLink1Description: https://datasheet.ciiva.com/16671/74hc-hct151-16671326.pdf?src-supplier=Avnet+Europe; ComponentLink1URL: https://datasheet.ciiva.com/16671/74hc-hct151-16671326.pdf?src-supplier=Avnet+Europe; Library Name: New_Parts.SchLib; Manufacturer Part Number: 74HCT151PW,118; Max Operating Temperature: 125°C; Min Operating Temperature: -40°C; Mount: Surface Mount; Number of Inputs: 8; Number of Output Lines: 1; Number of Outputs: 1; Number of Pins: 16; Package Quantity: 2500; Packaging: Tape and Reel; Pricing 1: 1=0.38000, 10=0.32700, 25=0.30560, 100=0.24440, 250=0.22692, 500=0.19200, 1000=0.14837 (USD); Radiation Hardening: No; RoHS: Yes; RoHS Compliant: Yes; Stock 1: 3419; Supplier 1: Digi-Key; Supplier Part Number 1: 1727-7967-1-ND
U3 = IC_NVT2010PW: NVT2010PW/TSSOP24/REEL13//; Manufacturer: NXP Semiconductors; Logic Function: Translator; Max Supply Voltage: 5.5V; Min Supply Voltage: 1V; Number of Bits: 10; Output Type: Voltage; Propagation Delay: 1.5ns; Case/Package: TSSOP; Category: Interface ICs; Component Kind: Standard; ComponentLink1Description: https://datasheet.ciiva.com/1899/nvt2008-nvt2010-1899186.pdf?src-supplier=Digi-Key; ComponentLink1URL: https://datasheet.ciiva.com/1899/nvt2008-nvt2010-1899186.pdf?src-supplier=Digi-Key; ComponentLink2Description: https://datasheet.ciiva.com/1899/nvt2008-nvt2010-1899187.pdf?src-supplier=Digi-Key; ComponentLink2URL: https://datasheet.ciiva.com/1899/nvt2008-nvt2010-1899187.pdf?src-supplier=Digi-Key; ComponentLink3Description: https://datasheet.ciiva.com/8244/nvt2008-nvt2010-8244294.pdf?src-supplier=Avnet; ComponentLink3URL: https://datasheet.ciiva.com/8244/nvt2008-nvt2010-8244294.pdf?src-supplier=Avnet; ComponentLink4Description: https://datasheet.ciiva.com/1899/nvt2008-nvt2010-1899185.pdf?src-supplier=Digi-Key; ComponentLink4URL: https://datasheet.ciiva.com/1899/nvt2008-nvt2010-1899185.pdf?src-supplier=Digi-Key; Lead Free: Lead Free; Library Name: New_Parts.SchLib; Manufacturer Part Number: NVT2010PW,118; Max Operating Temperature: 85°C; Min Operating Temperature: -40°C; Mount: Surface Mount; Number of Channels: 10; Number of Pins: 24; Package Quantity: 1; Packaging: Tape and Reel; Pricing 1: 1=1.02000, 10=0.91600, 25=0.86920, 100=0.83480 (USD); Radiation Hardening: No; RoHS: Yes; RoHS Compliant: Yes; Series: -; Stock 1: 3117; Supplier 1: Digi-Key; Supplier Part Number 1: 568-5299-1-ND; Turn-On Delay Time: 1.5ns
U4 = IC_74HCT153: IC MULTIPLEXER 4-INPUT 16TSSOP; Manufacturer: NXP Semiconductors; High Level Output Current: 4mA; Independent Circuits: 1; Logic Function: Multiplexer,Mux; Max Supply Voltage: 5.5V; Min Supply Voltage: 4.5V; Number of Input Lines: 8; Number of Output Lines: 2; Operating Supply Voltage: 5V; Propagation Delay: 14ns; Supply Type: Single; Case/Package: TSSOP; Category: Decoders and Multiplexers; Component Kind: Standard; ComponentLink1Description: https://datasheet.ciiva.com/8242/74hc-hct153-cnv-8242250.pdf?src-supplier=Avnet; ComponentLink1URL: https://datasheet.ciiva.com/8242/74hc-hct153-cnv-8242250.pdf?src-supplier=Avnet; ComponentLink2Description: https://datasheet.ciiva.com/1888/74hc-hct153-cnv-1888511.pdf?src-supplier=Digi-Key; ComponentLink2URL: https://datasheet.ciiva.com/1888/74hc-hct153-cnv-1888511.pdf?src-supplier=Digi-Key; Contact Plating: Gold; Lead Free: Lead Free; Library Name: New_Parts.SchLib; Low Level Output Current: 4mA; Manufacturer Part Number: 74HCT153PW,118; Max Operating Temperature: 125°C; Min Operating Temperature: -40°C; Mount: Surface Mount; Number of Inputs: 8; Number of Outputs: 2; Number of Pins: 16; Package Quantity: 2500; Packaging: Tape and Reel; Pricing 1: 1=0.61000, 10=0.53900, 25=0.50640, 100=0.41340, 250=0.38400, 500=0.32680, 1000=0.24020 (USD); Radiation Hardening: No; RoHS: Yes; RoHS Compliant: Yes; Series: 74HCT; Stock 1: 1563; Supplier 1: Digi-Key; Supplier Part Number 1: 1727-7968-1-ND
U5 = IC_ADS1115: TEXAS INSTRUMENTS - ADS1115IDGSR . - Analogue to Digital Converter, 16 bit, 860 SPS, Differential, Single Ended, I2C, Single, 2 V; Conversion Rate: 0.86KS/s; Integral Nonlinearity (INL): 1LSB; Max Supply Voltage: 5.5V; Min Supply Voltage: 2V; Number of A/D Converters: 1; Number of Bits: 16; Number of Inputs: 4; Polarity: Bipolar; Power Dissipation: 900uW; Resolution: 16b; Sampling Rate: 860S/s; Case/Package: VSSOP; Category: Analog to Digital Converters (ADCs); Component Kind: Standard; ComponentLink1Description: https://datasheet.ciiva.com/4031/47725463s8438921n7713-4031028.pdf?src-supplier=Arrow+Electronics; ComponentLink1URL: https://datasheet.ciiva.com/4031/47725463s8438921n7713-4031028.pdf?src-supplier=Arrow+Electronics; ComponentLink2Description: https://datasheet.ciiva.com/1304/ads1113-1304266.pdf?src-supplier=Digi-Key; ComponentLink2URL: https://datasheet.ciiva.com/1304/ads1113-1304266.pdf?src-supplier=Digi-Key; ComponentLink3Description: https://datasheet.ciiva.com/25993/sbas444b-25993205.pdf?src-supplier=Avnet+Europe; ComponentLink3URL: https://datasheet.ciiva.com/25993/sbas444b-25993205.pdf?src-supplier=Avnet+Europe; Contact Plating: Gold; Interface: I2C; Lead Free: Lead Free; Library Name: New_Parts.SchLib; Manufacturer: Texas Instruments; Manufacturer Part Number: ADS1115IDGSR; Max Operating Temperature: 125°C; Max Supply Voltage (DC): 5.5V; Min Operating Temperature: -40°C; Min Supply Voltage (DC): 2V; Number of Converters: 1; Number of Pins: 10; Package Quantity: 2500; Packaging: Tape and Reel; Pricing 1: 1=5.62000, 10=5.04600, 25=4.77000, 100=3.92200, 250=3.51920, 500=3.39200, 1000=2.81960 (USD); Radiation Hardening: No; REACH SVHC: No SVHC; RoHS: Yes; RoHS Compliant: Yes; Series: -; Stock 1: 7435; Supplier 1: Digi-Key; Supplier Part Number 1: 296-38849-1-ND; Supply Type: Single
